# Altium schematic sheet FANONOFF.SchDoc
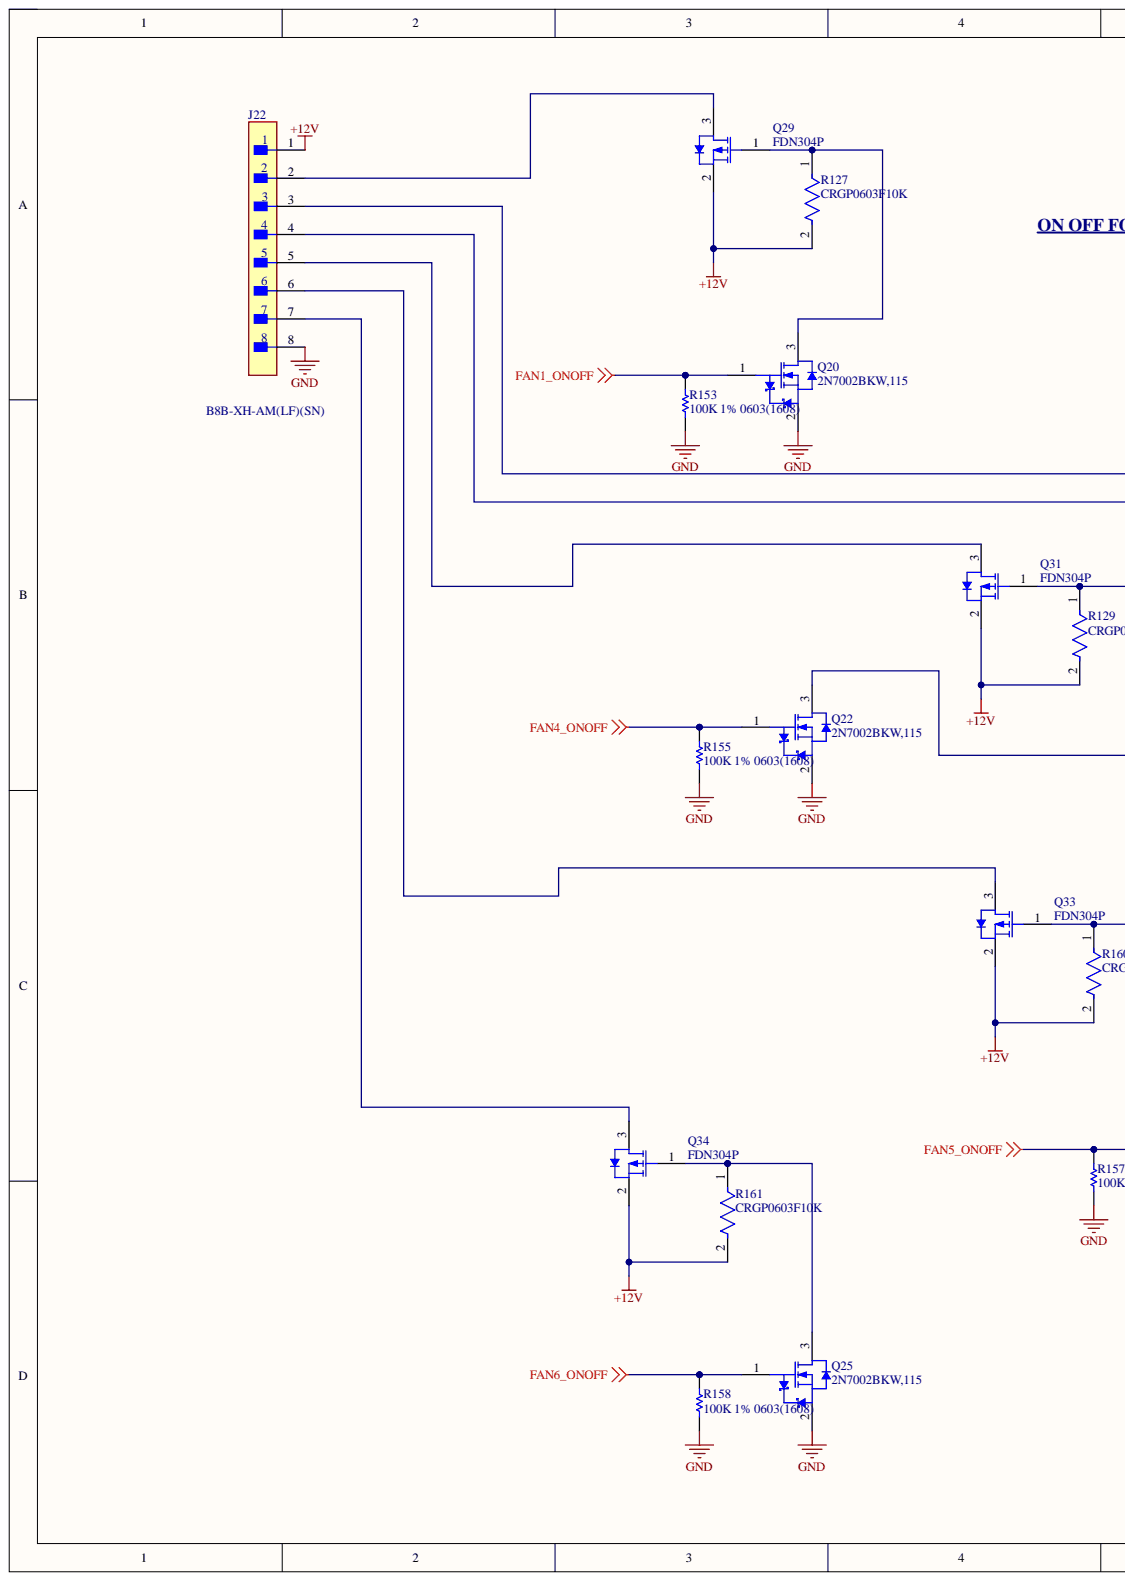
[diagram p0: FANONOFF.SchDoc, left]
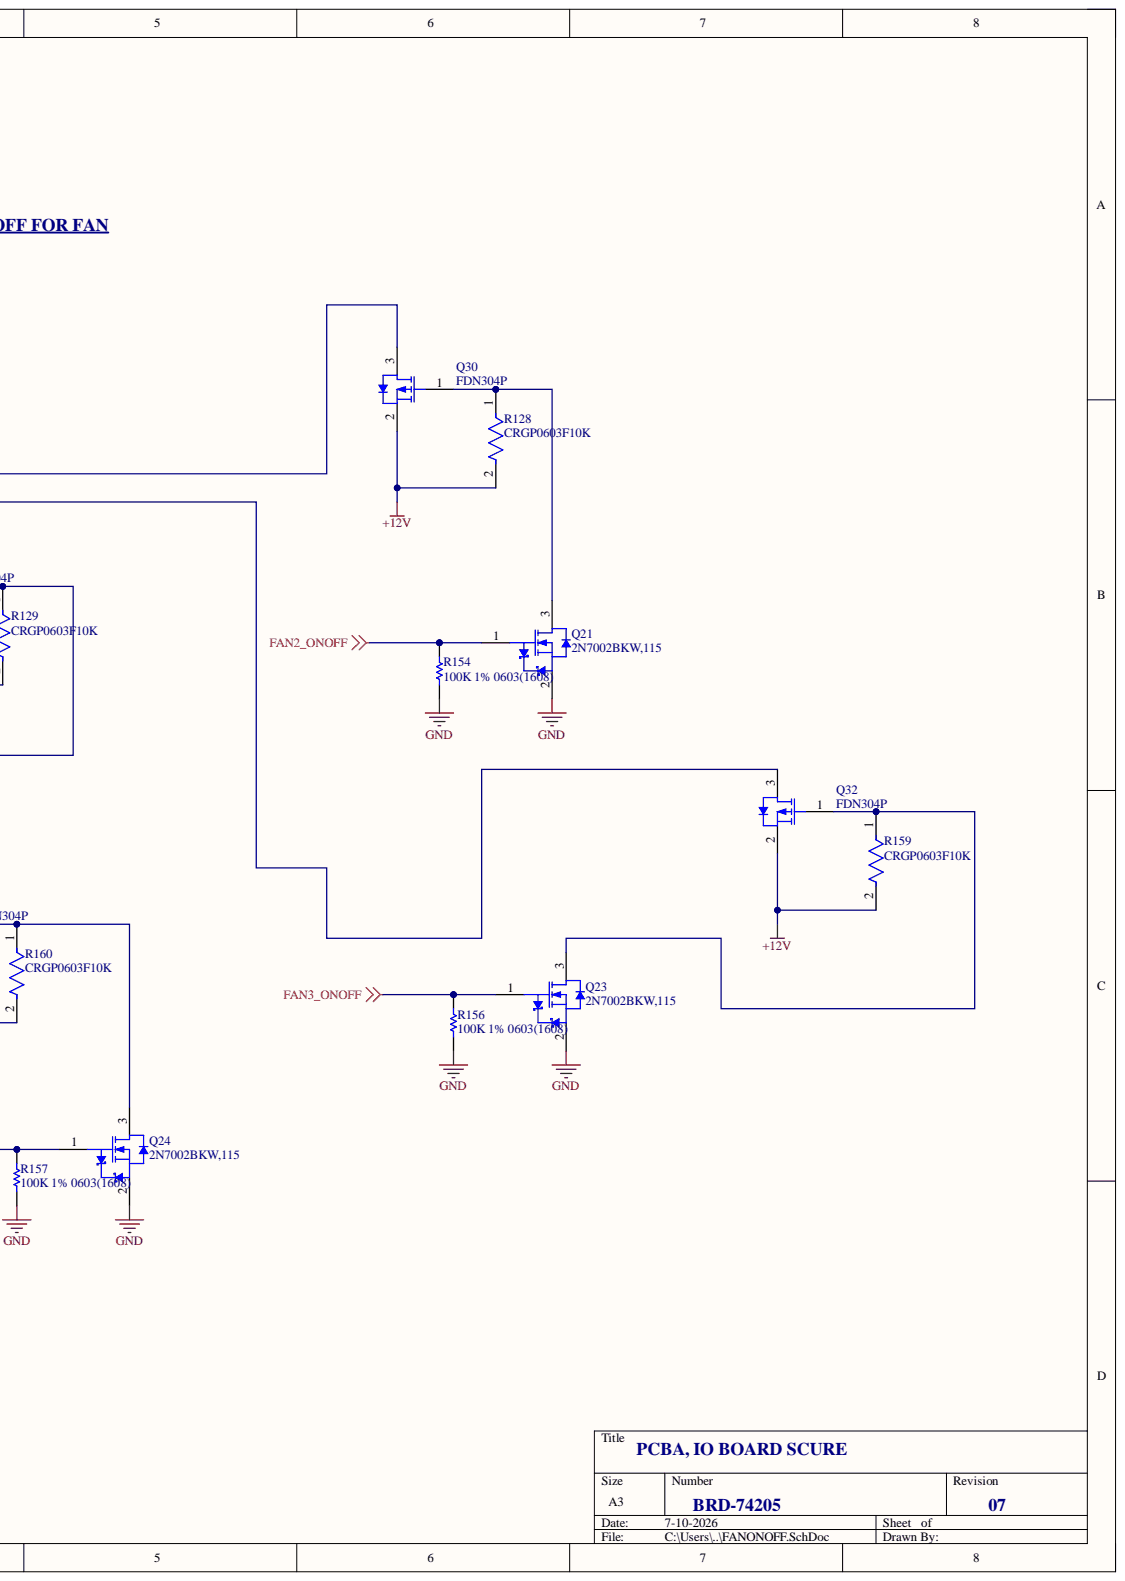
[diagram p1: FANONOFF.SchDoc, right]

## Parts
J22  B8B-XH-AM(LF)(SN)  [FP-B8B-XH-AM_LF_SN-MFG]
Q20  2N7002BKW,115  [NXP-SOT323_N]
Q21  2N7002BKW,115  [NXP-SOT323_N]
Q22  2N7002BKW,115  [NXP-SOT323_N]
Q23  2N7002BKW,115  [NXP-SOT323_N]
Q24  2N7002BKW,115  [NXP-SOT323_N]
Q25  2N7002BKW,115  [NXP-SOT323_N]
Q29  FDN304P  [FAIR-SSOT3L-3_V]
Q30  FDN304P  [FAIR-SSOT3L-3_V]
Q31  FDN304P  [FAIR-SSOT3L-3_V]
Q32  FDN304P  [FAIR-SSOT3L-3_V]
Q33  FDN304P  [FAIR-SSOT3L-3_V]
Q34  FDN304P  [FAIR-SSOT3L-3_V]
R127  CRGP0603F10K  [FP-CRGP0603-IPC_C]
R128  CRGP0603F10K  [FP-CRGP0603-IPC_C]
R129  CRGP0603F10K  [FP-CRGP0603-IPC_C]
R153  100K 1% 0603(1608)  [RESC0603(1608)_L]
R154  100K 1% 0603(1608)  [RESC0603(1608)_L]
R155  100K 1% 0603(1608)  [RESC0603(1608)_L]
R156  100K 1% 0603(1608)  [RESC0603(1608)_L]
R157  100K 1% 0603(1608)  [RESC0603(1608)_L]
R158  100K 1% 0603(1608)  [RESC0603(1608)_L]
R159  CRGP0603F10K  [FP-CRGP0603-IPC_C]
R160  CRGP0603F10K  [FP-CRGP0603-IPC_C]
R161  CRGP0603F10K  [FP-CRGP0603-IPC_C]

## Nets
+12V: J22.1 Q29.2 Q30.2 Q31.2 Q32.2 Q33.2 Q34.2 R127.2 R128.2 R129.2 R159.2 R160.2 R161.2
FAN1_ONOFF: Q20.1 R153.2
FAN2_ONOFF: Q21.1 R154.2
FAN3_ONOFF: Q23.1 R156.2
FAN4_ONOFF: Q22.1 R155.2
FAN5_ONOFF: Q24.1 R157.2
FAN6_ONOFF: Q25.1 R158.2
GND: J22.8 Q20.2 Q21.2 Q22.2 Q23.2 Q24.2 Q25.2 R153.1 R154.1 R155.1 R156.1 R157.1 R158.1
NetJ22_2: J22.2 Q29.3
NetJ22_3: J22.3 Q30.3
NetJ22_4: J22.4 Q32.3
NetJ22_5: J22.5 Q31.3
NetJ22_6: J22.6 Q33.3
NetJ22_7: J22.7 Q34.3
NetQ20_3: Q20.3 Q29.1 R127.1
NetQ21_3: Q21.3 Q30.1 R128.1
NetQ22_3: Q22.3 Q31.1 R129.1
NetQ23_3: Q23.3 Q32.1 R159.1
NetQ24_3: Q24.3 Q33.1 R160.1
NetQ25_3: Q25.3 Q34.1 R161.1

## Library data of the parts
J22 = CMP-17439-000637-1: CONN HEADER VERT 8POS 2.5MM; Mated Stacking Heights: 9.8mm; Number of Positions: 8; Voltage Rating: 250V; Color: Green; Component Kind: Standard; Connector Type: Header; Contact Finish - Mating: TIN; Contact Material: Brass; Contact Plating: Tin; Contact Shape: Square; Contact Type: Male Pin; Fastening Type: Detent; Flammability Rating: UL94 V-0; Insulation Color: Natural; Insulation Material: Nylon; Lead Free: Lead Free; Library Name: Altium Content Vault; Mount: Through Hole; Number of Rows: 1; Packaging: Bulk; Pitch: 2.5mm; Series: XH; Termination: Press-Fit
Q20-Q25 = CMP-1259-00106-1: N-Channel Trench MOSFET, 60 V, 0.31 A, -55 to 150 degC, 3-Pin SOT323, Tape and Reel; Manufacturer: NXP Semiconductors; Automotive Qualified: Y; Channel Type: N; ID [max] (A): 0.31; IDM [max] (A): 1.2; Packing: Tape & Reel; VDS [max] (V): 60; VGSth [typ] (V): 1.6; Code_JEITA: SC-70; Component Kind: Standard; ComponentLink1Description: Manufacturer URL; ComponentLink1URL: http://www.nxp.com/; ComponentLink2Description: Datasheet; ComponentLink2URL: http://www.nxp.com/documents/data_sheet/2N7002BKW.pdf; ComponentLink3Description: Package Specification; ComponentLink3URL: http://www.nxp.com/documents/outline_drawing/sot323_po.pdf; DatasheetVersion: Rev. 1; Library Name: Altium Content Vault; Mounting Technology: Surface Mount; PackageDescription: 3-Pin Small Outline Transistor Package, Body 2 x 1.25 mm, Pitch 0.65 mm; PackageReference: SOT323; PackageVersion: 06-03-16, 03/2006; PartNumber: 2N7002BKW,115
Q29-Q34 = CMP-2000-06247-1: P-Channel Specified Power Trench(R) MOSFET, 1.8 V, -55 to 150 degC, 3-Pin SSOT, RoHS, Tape and Reel; Manufacturer: Fairchild Semiconductor; Continuous Drain Current Id: 2.4A; Current Temperature: 25°C; Drain Source Voltage Vds: 20V; External Depth: 2.5mm; External Length / Height: 1.12mm; External Width: 3.05mm; No. of Pins: 3; On Resistance Rds(on): 52mohm; Package Version: Rev. 3, 05/2015; Power Dissipation Pd: 500mW; Pulse Current Idm: 10A; Rds(on) Test Voltage Vgs: -4.5V; Reel Quantity: 3000; SMD Marking: 304P; SVHC: NoSVHC(19-Dec-2012); Threshold Voltage Vgs Typ: -800mV; Transistor Case Style: SOT-23; Transistor Polarity: PChannel; Voltage Vds Typ: -20V; Voltage Vgs th Max: -1.5V; Component Kind: Standard; Current Id Max: 2.4A; Datasheet URL: https://www.fairchildsemi.com/datasheets/FD/FDN304P.pdf; Datasheet Version: Rev. C, 01/2001; Full Power Rating Temperature: 25°C; Library Name: Altium Content Vault; Manufacturer URL: https://www.fairchildsemi.com/; Mounting Technology: SurfaceMount; Operating Temperature Max: 150°C; Operating Temperature Min: -55°C; Operating Temperature Range: -55°Cto+150°C; Package / Case: SOT-23; Package Description: 3-Pin Small Outline Transistor, Body 2.92 x 1.4 mm, Pitch 0.95 mm; Package Reference: SSOT3L; Package URL: https://www.fairchildsemi.com/package-drawings/MA/MA03B.pdf; Tape Width: 8mm; Termination Type: SMD; Voltage Vds: 20V; Voltage Vgs Max: -800mV; Voltage Vgs Rds on Measurement: -4.5V
R127-R129 R159-R161 = CMP-03211-004414-1: CRGP 0603 10K 1%; Manufacturer: TE; Power Rating: 0.25W; Resistance: 10KΩ; Temperature Coefficient: ±100ppm/°C; Tolerance: 0.01; Value: 10KΩ; Voltage Rating: 50V; Ambient Temperature Range High: +70°C; Automotive: No; Category: Res; Component Kind: Standard; ComponentLink2Description: Datasheet; ComponentLink2URL: https://www.te.com/commerce/DocumentDelivery/DDEController?Action=srchrtrv&DocNm=9-1773463-9&DocType=DS&DocLang=English; Composition: Thick Film; Device Class L1: Passive Components; Device Class L2: Resistors; Device Class L3: Chip SMD Resistors; Digikey Description: CRGP 0603 10K 1%; Height: 0.55mm; Ipc Land Pattern Name: RESC16080X45; Lead Free: Yes; Library Name: Altium Content Vault; Manufacturer Part Number: CRGP0603F10K; Mouser Description: Res, 10K, 1%, 0.25W, 0603, Thick Film; Octopart: https://octopart.com/crgp0603f10k-te+connectivity-91018721; Package: CRGP0603; RoHS: TRUE; Series: CRGP; Temperature: -55°C to +155°C; Temperature Range High: +155°C; Temperature Range Low: -55°C
R153-R158 = CMP-1012-00736-1: 100K 0.1W 1% 0603 (1608 Metric)  SMD; Case-EIA: 0603; Case-Metric: 1608; Value: 100000.00 Ohm; Component Kind: Standard; Library Name: Altium Content Vault; Power: 0.1 W; Technology: SMT; Tolerance: 1 %
